# Altium schematic sheet M2_Conn.SchDoc(M2_Conn)
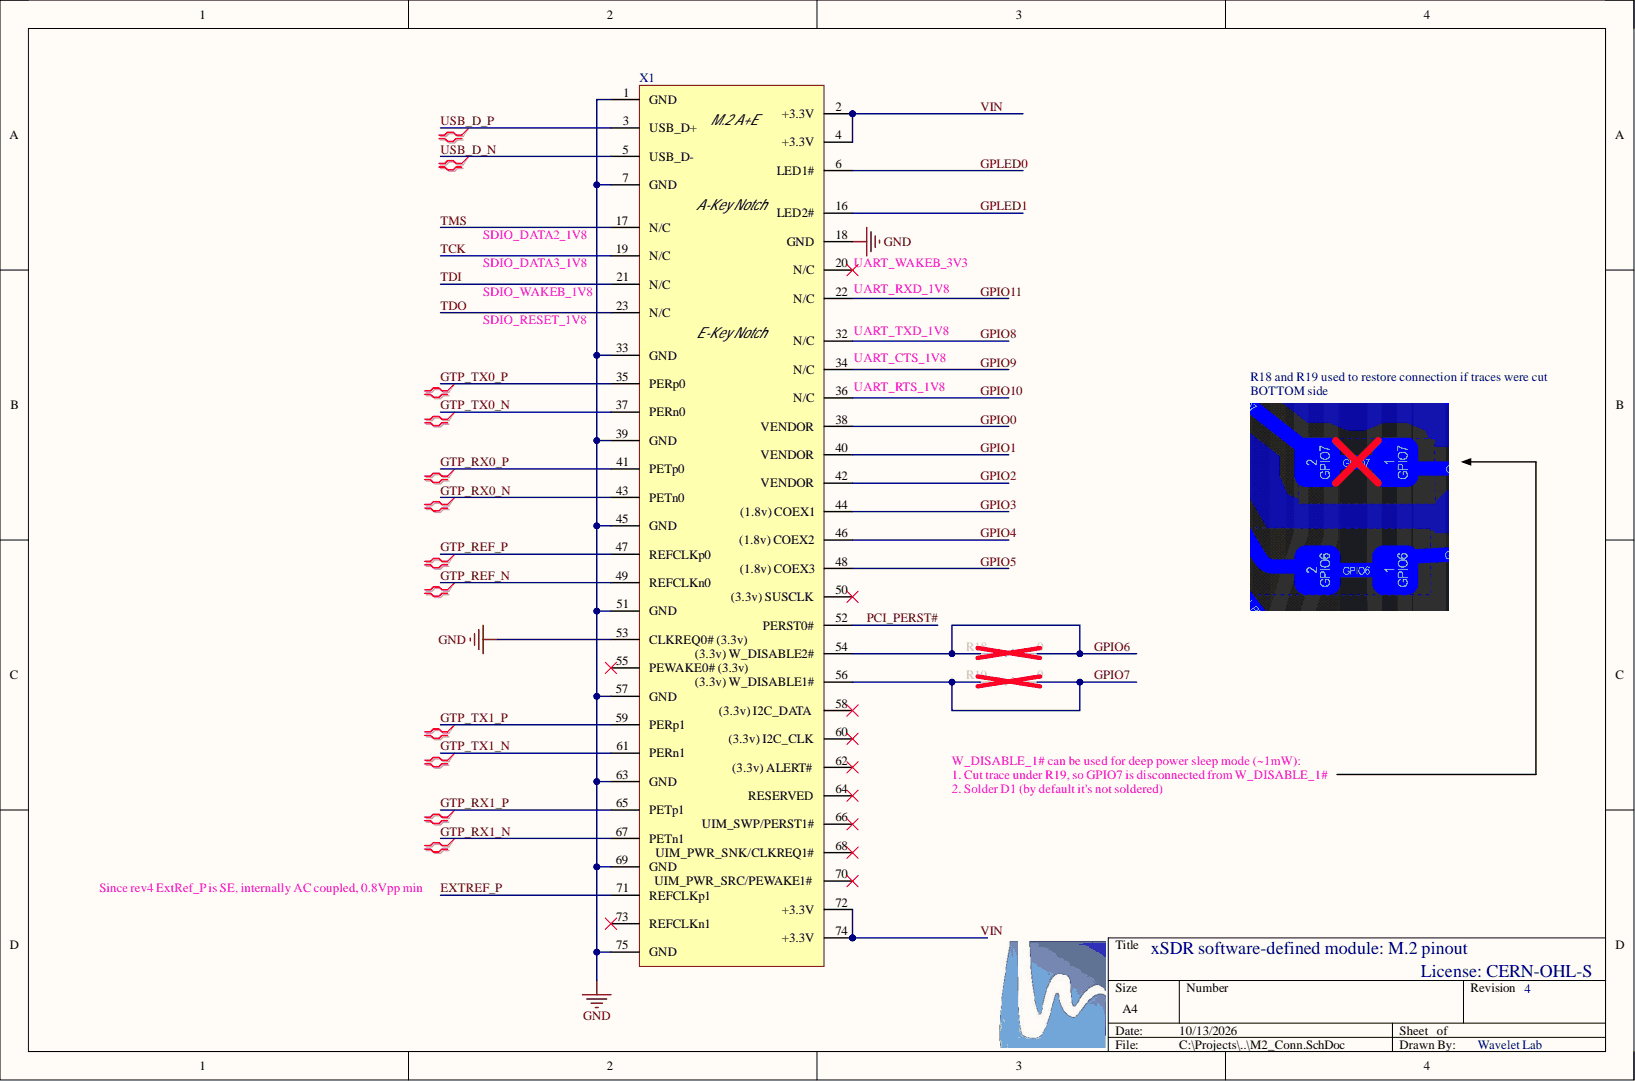
[diagram p0: M2_Conn.SchDoc]

## Parts
R18  0  [SMD-0201-RES]
R19  0  [SMD-0201-RES]
X1  (no value)  [Conn Edge M.2 A/E Key]  (no BOM)

## Nets
GND: X1.1(GND) X1.7(GND) X1.18(GND) X1.33(GND) X1.39(GND) X1.45(GND) X1.51(GND) X1.53(CLKREQ0#_(3.3v)) X1.57(GND) X1.63(GND) X1.69(GND) X1.75(GND)
EXTREF_P: X1.71(REFCLKp1)
GPIO0: X1.38(VENDOR)
GPIO1: X1.40(VENDOR)
GPIO2: X1.42(VENDOR)
GPIO3: X1.44((1.8v)_COEX1)
GPIO4: X1.46((1.8v)_COEX2)
GPIO5: X1.48((1.8v)_COEX3)
GPIO6: R18.1 R18.2 X1.54((3.3v)_W_DISABLE2#)
GPIO7: R19.1 R19.2 X1.56((3.3v)_W_DISABLE1#)
GPIO8: X1.32(N/C)
GPIO9: X1.34(N/C)
GPIO10: X1.36(N/C)
GPIO11: X1.22(N/C)
GPLED0: X1.6(LED1#)
GPLED1: X1.16(LED2#)
GTP_REF_N: X1.49(REFCLKn0)
GTP_REF_P: X1.47(REFCLKp0)
GTP_RX0_N: X1.43(PETn0)
GTP_RX0_P: X1.41(PETp0)
GTP_RX1_N: X1.67(PETn1)
GTP_RX1_P: X1.65(PETp1)
GTP_TX0_N: X1.37(PERn0)
GTP_TX0_P: X1.35(PERp0)
GTP_TX1_N: X1.61(PERn1)
GTP_TX1_P: X1.59(PERp1)
PCI_PERST#: X1.52(PERST0#)
TCK: X1.19(N/C)
TDI: X1.21(N/C)
TDO: X1.23(N/C)
TMS: X1.17(N/C)
USB_D_N: X1.5(USB_D-)
USB_D_P: X1.3(USB_D+)
VIN: X1.2(+3.3V) X1.4(+3.3V) X1.72(+3.3V) X1.74(+3.3V)

Not connected: X1 20(N/C) 50((3.3v)_SUSCLK) 55(PEWAKE0#_(3.3v)) 58((3.3v)_I2C_DATA) 60((3.3v)_I2C_CLK) 62((3.3v)_ALERT#) 64(RESERVED) 66(UIM_SWP/PERST1#) 68(UIM_PWR_SNK/CLKREQ1#) 70(UIM_PWR_SRC/PEWAKE1#) 73(REFCLKn1)

## Library data of the parts
R18 R19 = ERJ-1GEJ103C: RES SMD 200K 5% 1/20W 0201; Manufacturer: Panasonic Electronic Components; Part Number: ERJ-1GEJ204C; @ qty: 1500; Minimum Quantity: 1; Power (Watts): 0.05W, 1/20W; Temperature Coefficient: ±200ppm/°C; Unit Price (USD): 0.00787; Component Kind: Standard; Composition: Thick Film; Datasheets: //media.digikey.com/pdf/Data%20Sheets/Panasonic%20Resistors%20Thermistors%20PDFs/ERJ%205%25.pdf; Digi-Key Part Number: P10KAGCT-ND; Factory Stock: 0; Failure Rate: -; Features: -; Height - Seated (Max): 0.010" (0.26mm); Image: //media.digikey.com/Renders/Panasonic%20Renders/ERJ-0201%20Pkg.jpg; Library Name: Resistors.IntLib; Number of Terminations: 2; Operating Temperature: -55°C ~ 125°C; Package / Case: 0201 (0603 Metric); Packaging: Cut Tape (CT); Part Status: Active; Quantity Available: 115724; Series: ERJ; Size / Dimension: 0.024" L x 0.012" W (0.60mm x 0.30mm); Supplier Device Package: 0201; Tolerance: ±5%; Value: 0
X1 = M2TRX M.2 A/E-Key Edge Conn: M.2 A/E-Key Card Golden Finger Edge Connector; System: Connector; Library Name: USDR.IntLib
